# Altium schematic sheet schematic.SchDoc(schematic)
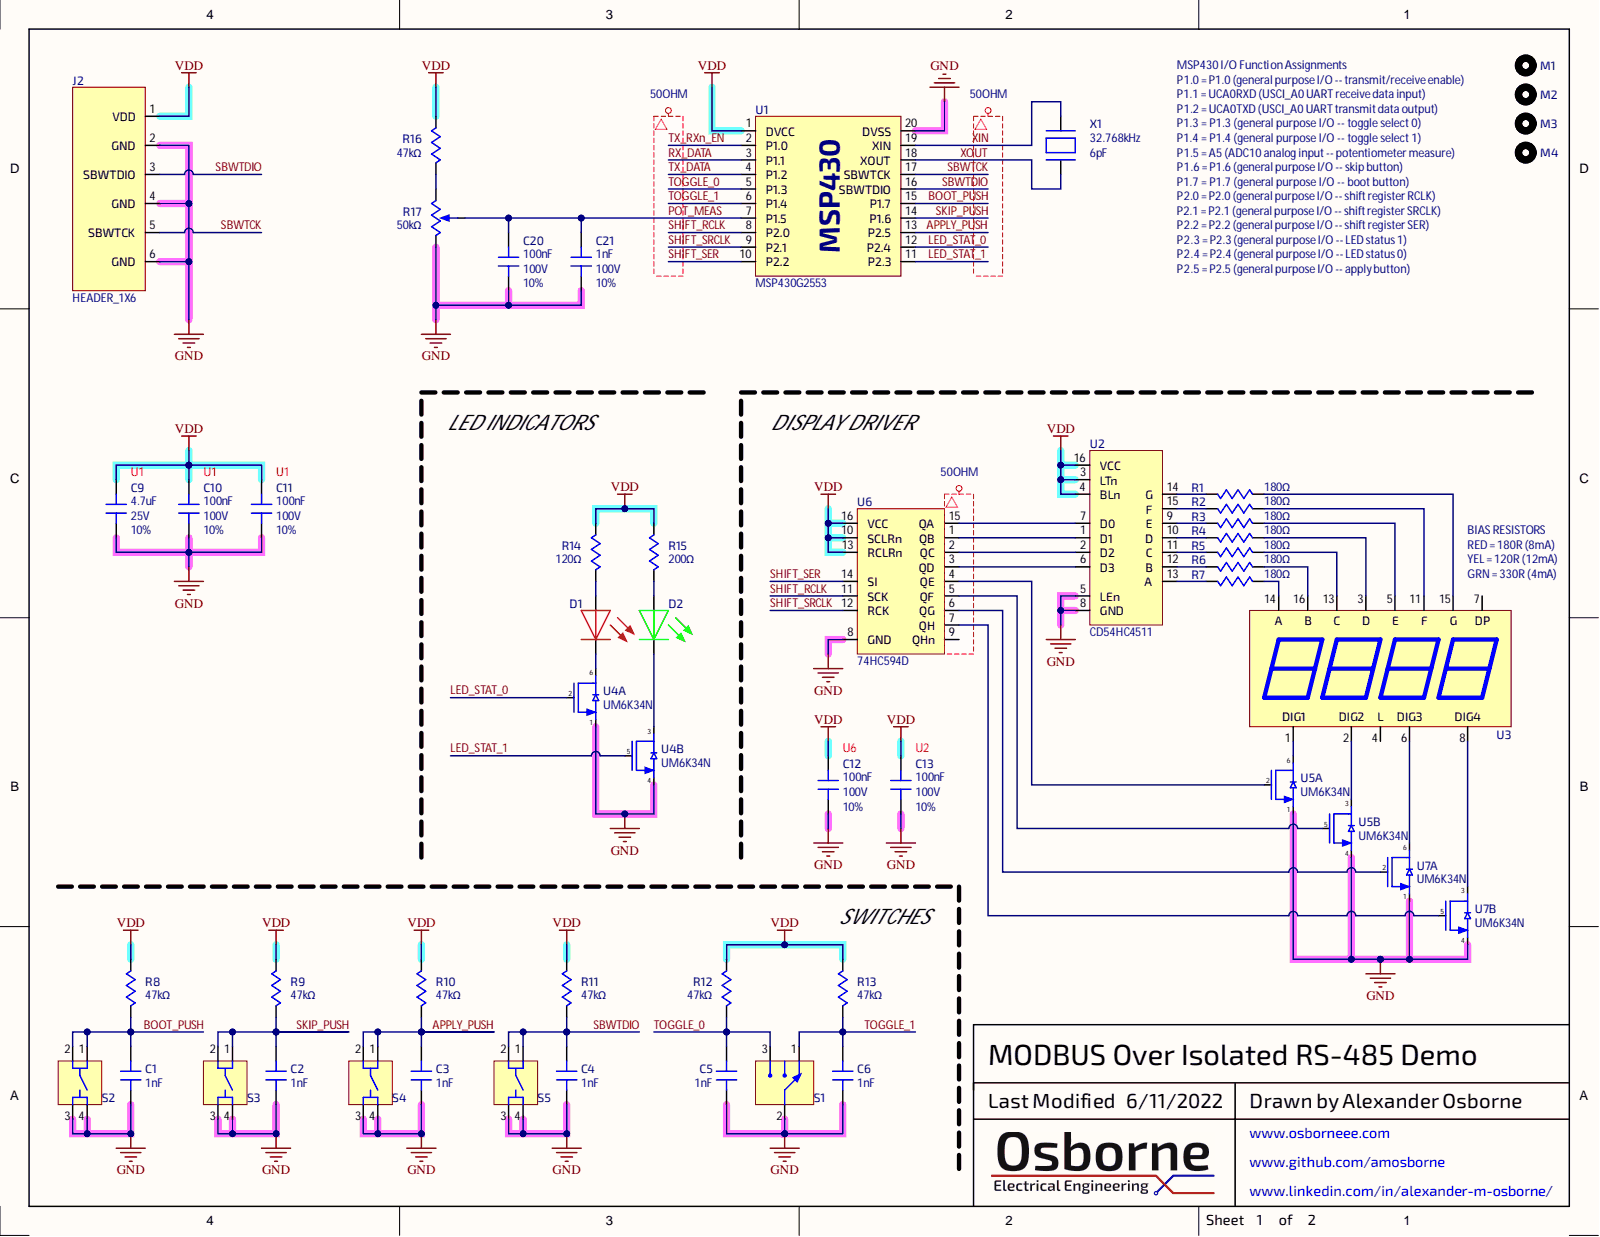
[diagram p0: schematic.SchDoc]

## Parts
C1  value 1nF  [C0805]  (hidden comment: CAP-CER-1N00-10T-100V-0805)
C2  value 1nF  [C0805]  (hidden comment: CAP-CER-1N00-10T-100V-0805)
C3  value 1nF  [C0805]  (hidden comment: CAP-CER-1N00-10T-100V-0805)
C4  value 1nF  [C0805]  (hidden comment: CAP-CER-1N00-10T-100V-0805)
C5  value 1nF  [C0805]  (hidden comment: CAP-CER-1N00-10T-100V-0805)
C6  value 1nF  [C0805]  (hidden comment: CAP-CER-1N00-10T-100V-0805)
C9  value 4.7uF  Tolerance: 10%  Voltage: 25V  [C0805]  (hidden comment: CAP-CER-4U70-10T-25V-0805)
C10  value 100nF  Tolerance: 10%  Voltage: 100V  [C0805]  (hidden comment: CAP-CER-100N-10T-100V-0805)
C11  value 100nF  Tolerance: 10%  Voltage: 100V  [C0805]  (hidden comment: CAP-CER-100N-10T-100V-0805)
C12  value 100nF  Tolerance: 10%  Voltage: 100V  [C0805]  (hidden comment: CAP-CER-100N-10T-100V-0805)
C13  value 100nF  Tolerance: 10%  Voltage: 100V  [C0805]  (hidden comment: CAP-CER-100N-10T-100V-0805)
C20  value 100nF  Tolerance: 10%  Voltage: 100V  [C0805]  (hidden comment: CAP-CER-100N-10T-100V-0805)
C21  value 1nF  Tolerance: 10%  Voltage: 100V  [C0805]  (hidden comment: CAP-CER-1N00-10T-100V-0805)
D1  LED_RED  [D0805]  (value not drawn)
D2  LED_GREEN  [D0805]  (value not drawn)
J2  HEADER_1X6  [Header_1x6]
M1  MTG_HOLE  [MTG_HOLE_No4]  (value not drawn)  (no BOM)
M2  MTG_HOLE  [MTG_HOLE_No4]  (value not drawn)  (no BOM)
M3  MTG_HOLE  [MTG_HOLE_No4]  (value not drawn)  (no BOM)
M4  MTG_HOLE  [MTG_HOLE_No4]  (value not drawn)  (no BOM)
R1  value 180Ω  [R0805]  (hidden comment: RES-180R-1T00-W125-0805)
R2  value 180Ω  [R0805]  (hidden comment: RES-180R-1T00-W125-0805)
R3  value 180Ω  [R0805]  (hidden comment: RES-180R-1T00-W125-0805)
R4  value 180Ω  [R0805]  (hidden comment: RES-180R-1T00-W125-0805)
R5  value 180Ω  [R0805]  (hidden comment: RES-180R-1T00-W125-0805)
R6  value 180Ω  [R0805]  (hidden comment: RES-180R-1T00-W125-0805)
R7  value 180Ω  [R0805]  (hidden comment: RES-180R-1T00-W125-0805)
R8  value 47kΩ  [R0805]  (hidden comment: RES-47K0-1T00-W125-0805)
R9  value 47kΩ  [R0805]  (hidden comment: RES-47K0-1T00-W125-0805)
R10  value 47kΩ  [R0805]  (hidden comment: RES-47K0-1T00-W125-0805)
R11  value 47kΩ  [R0805]  (hidden comment: RES-47K0-1T00-W125-0805)
R12  value 47kΩ  [R0805]  (hidden comment: RES-47K0-1T00-W125-0805)
R13  value 47kΩ  [R0805]  (hidden comment: RES-47K0-1T00-W125-0805)
R14  value 120Ω  [R0805]  (hidden comment: RES-120R-1T00-W125-0805)
R15  value 200Ω  [R0805]  (hidden comment: RES-200R-1T00-W125-0805)
R16  value 47kΩ  [R0805]  (hidden comment: RES-47K0-1T00-W125-0805)
R17  value 50kΩ  [3352T_Trimpot]  (hidden comment: RES-50K0-TRIMMER)
S1  SPDT-TOGGLE-SWITCH  [AST13SNCQ]  (value not drawn)
S2  PTS647  [PTS647]  (value not drawn)
S3  PTS647  [PTS647]  (value not drawn)
S4  PTS647  [PTS647]  (value not drawn)
S5  PTS647  [PTS647]  (value not drawn)
U1  MSP430G2553  [MSP430G2553_TSSOP-20]
U2  CD54HC4511  [CD54HC4511_SOIC-16]
U3  QUAD-7SEG-DISP  [LTC-4727]  (value not drawn)
U4  UM6K34N  [UM6K34N_SC-88]  (parts A,B)
U5  UM6K34N  [UM6K34N_SC-88]  (parts A,B)
U6  74HC594D  [74HC594D_SOIC-16]
U7  UM6K34N  [UM6K34N_SC-88]  (parts A,B)
X1  Capacitance: 6pF  Frequency: 32.768kHz  [CRYSTAL_THT_2x6]  (hidden comment: 830014219B)

## Nets
GND: C1.2 C2.2 C3.2 C4.2 C5.2 C6.2 C9.2 C10.2 C11.2 C12.2 C13.2 C20.2 C21.2 J2.2(GND) J2.4(GND) J2.6(GND) R17.3 S1.2 S2.3 S2.4 S3.3 S3.4 S4.3 S4.4 S5.3 S5.4 U1.20(DVSS) U2.5(LEn) U2.8(GND) U4.1 U4.4 U5.1 U5.4 U6.8(GND) U7.1 U7.4
VDD: C9.1 C10.1 C11.1 C12.1 C13.1 J2.1(VDD) R8.1 R9.1 R10.1 R11.1 R12.1 R13.1 R14.1 R15.1 R16.1 U1.1(DVCC) U2.3(LTn) U2.4(BLn) U2.16(VCC) U6.10(SCLRn) U6.13(RCLRn) U6.16(VCC)
APPLY_PUSH: C3.1 R10.2 S4.1 S4.2 U1.13(P2.5)
BOOT_PUSH: C1.1 R8.2 S2.1 S2.2 U1.15(P1.7)
LED_STAT_0: U1.12(P2.4) U4.2
LED_STAT_1: U1.11(P2.3) U4.5
POT_MEAS: C20.1 C21.1 R17.2 U1.7(P1.5)
RX_DATA: U1.3(P1.1)
SBWTCK: J2.5(SBWTCK) U1.17(SBWTCK)
SBWTDIO: C4.1 J2.3(SBWTDIO) R11.2 S5.1 S5.2 U1.16(SBWTDIO)
SHIFT_RCLK: U1.8(P2.0) U6.11(SCK)
SHIFT_SER: U1.10(P2.2) U6.14(SI)
SHIFT_SRCLK: U1.9(P2.1) U6.12(RCK)
SKIP_PUSH: C2.1 R9.2 S3.1 S3.2 U1.14(P1.6)
TOGGLE_0: C5.1 R12.2 S1.3 U1.5(P1.3)
TOGGLE_1: C6.1 R13.2 S1.1 U1.6(P1.4)
TX_DATA: U1.4(P1.2)
TX_RXn_EN: U1.2(P1.0)
XIN: U1.19(XIN) X1.1
XOUT: U1.18(XOUT) X1.2
NetD1_1: D1.1 U4.6
NetD1_2: D1.2 R14.2
NetD2_1: D2.1 U4.3
NetD2_2: D2.2 R15.2
NetR1_1: R1.1 U2.14(G)
NetR1_2: R1.2 U3.15(G)
NetR2_1: R2.1 U2.15(F)
NetR2_2: R2.2 U3.11(F)
NetR3_1: R3.1 U2.9(E)
NetR3_2: R3.2 U3.5(E)
NetR4_1: R4.1 U2.10(D)
NetR4_2: R4.2 U3.3(D)
NetR5_1: R5.1 U2.11(C)
NetR5_2: R5.2 U3.13(C)
NetR6_1: R6.1 U2.12(B)
NetR6_2: R6.2 U3.16(B)
NetR7_1: R7.1 U2.13(A)
NetR7_2: R7.2 U3.14(A)
NetR16_2: R16.2 R17.1
NetU2_1: U2.1(D1) U6.1(QB)
NetU2_2: U2.2(D2) U6.2(QC)
NetU2_6: U2.6(D3) U6.3(QD)
NetU2_7: U2.7(D0) U6.15(QA)
NetU3_1: U3.1 U5.6
NetU3_2: U3.2 U5.3
NetU3_6: U3.6 U7.6
NetU3_8: U3.8 U7.3
NetU5_2: U5.2 U6.4(QE)
NetU5_5: U5.5 U6.5(QF)
NetU6_6: U6.6(QG) U7.2
NetU6_7: U6.7(QH) U7.5

Not connected: U3 4(L) 7(DP); U6 9(QHn)

## Library data of the parts
C1-C6 C21 = CAP-CER-1N00-10T-100V-0805: CAP, CER, 1nF ±10%, 100V, 0805; Component Kind: Standard; Library Name: schematic_library.SchLib; Supplier 1: Digi-Key; Supplier Part Number 1: 732-12102-1-ND
  C1-C6: Tolerance: 10%; Voltage: 100V
C9 = CAP-CER-4U70-10T-25V-0805: CAP, CER, 4.7uF ±10%, 25V, 0805; Component Kind: Standard; Library Name: schematic_library.SchLib; Supplier 1: Digi-Key; Supplier Part Number 1: 1276-2969-1-ND
C10-C13 C20 = CAP-CER-100N-10T-100V-0805: CAP, CER, 100nF ±10%, 100V, 0805; Component Kind: Standard; Library Name: schematic_library.SchLib; Supplier 1: Digi-Key; Supplier Part Number 1: 732-12244-1-ND
D1 = LED_RED: Red LED Indicator 0805; Component Kind: Standard; Library Name: schematic_library.SchLib; Supplier 1: Digi-Key; Supplier Part Number 1: 732-4984-1-ND
D2 = LED_GREEN: Green LED Indicator 0805; Component Kind: Standard; Library Name: schematic_library.SchLib; Supplier 1: Digi-Key; Supplier Part Number 1: 732-4983-1-ND
J2 = HEADER_1X6: Header, 1-Row, 6-Position; Component Kind: Standard; Library Name: schematic_library.SchLib
M1-M4 = MTG_HOLE: Mounting Hole; Library Name: schematic_library.SchLib
R1-R7 = RES-180R-1T00-W125-0805: RES, 180Ω ±1.00%, 125mW, 0805; Tolerance: 1%; Component Kind: Standard; Library Name: schematic_library.SchLib; Power: 125mW; Supplier 1: Digi-Key; Supplier Part Number 1: 13-RT0805FRE07180RLCT-ND
R8-R13 R16 = RES-47K0-1T00-W125-0805: RES, 47.0kΩ ±1.00%, 125mW, 0805; Tolerance: 1%; Component Kind: Standard; Library Name: schematic_library.SchLib; Power: 125mW; Supplier 1: Digi-Key; Supplier Part Number 1: A129769CT-ND
R14 = RES-120R-1T00-W125-0805: RES, 120Ω ±1.00%, 125mW, 0805; Tolerance: 1%; Component Kind: Standard; Library Name: schematic_library.SchLib; Power: 125mW; Supplier 1: Digi-Key; Supplier Part Number 1: A129738CT-ND
R15 = RES-200R-1T00-W125-0805: RES, 200Ω ±1.00%, 125mW, 0805; Tolerance: 1%; Component Kind: Standard; Library Name: schematic_library.SchLib; Power: 125mW; Supplier 1: Digi-Key; Supplier Part Number 1: 13-RT0805FRE07200RLCT-ND
R17 = RES-50K0-TRIMMER: 50kOhm Thumbwheel Potentiometer; Component Kind: Standard; Library Name: schematic_library.SchLib; Supplier 1: Digi-Key; Supplier Part Number 1: 3352T-503LF-ND
S1 = SPDT-TOGGLE-SWITCH: SPDT Toggle Switch, On-Off-On, Through Hole; Component Kind: Standard; Library Name: schematic_library.SchLib; Supplier 1: Digi-Key; Supplier Part Number 1: 2449-AST13SNCQ-ND
S2-S5 = PTS647: PTS647 Series Tactile Switch; Component Kind: Standard; Library Name: schematic_library.SchLib; Supplier 1: Digi-Key; Supplier Part Number 1: PTS647SN70SMTR2LFSCT-ND
U1 = MSP430G2553: MSP430 16-BIT, 16KB FLASH, 20-TSSOP; Component Kind: Standard; Library Name: schematic_library.SchLib; Supplier 1: Digi-Key; Supplier Part Number 1: 296-28430-1-ND
U2 = CD54HC4511: BCD Decoder, 7-Segment Display Driver; Component Kind: Standard; Library Name: schematic_library.SchLib; Supplier 1: Digi-Key; Supplier Part Number 1: 296-CD74HC4511MTCT-ND
U3 = QUAD-7SEG-DISP: Quad 7-Segment Display, Common Cathode; Component Kind: Standard; Library Name: schematic_library.SchLib; Supplier 1: Digi-Key; Supplier 2: Digi-Key; Supplier Part Number 1: 160-1551-5-ND; Supplier Part Number 2: 160-1547-5-ND
U4 U5 U7 = UM6K34N: NCH+NCH 50V 200mA Small Signal MOSFET; Component Kind: Standard; Library Name: schematic_library.SchLib; Supplier 1: Digi-Key; Supplier Part Number 1: UM6K34NTCNCT-ND
U6 = 74HC594D: Shift Register, 8-Bit; Component Kind: Standard; Library Name: schematic_library.SchLib; Supplier 1: Digi-Key; Supplier Part Number 1: 74HC594DCT-ND
X1 = 830014219B: 32.768kHz Tuning Fork Crystal, 6pF; Component Kind: Standard; Library Name: schematic_library.SchLib; Supplier 1: Digi-Key; Supplier Part Number 1: 1923-830014219B-ND
